# Altium schematic sheet CTRL.SchDoc
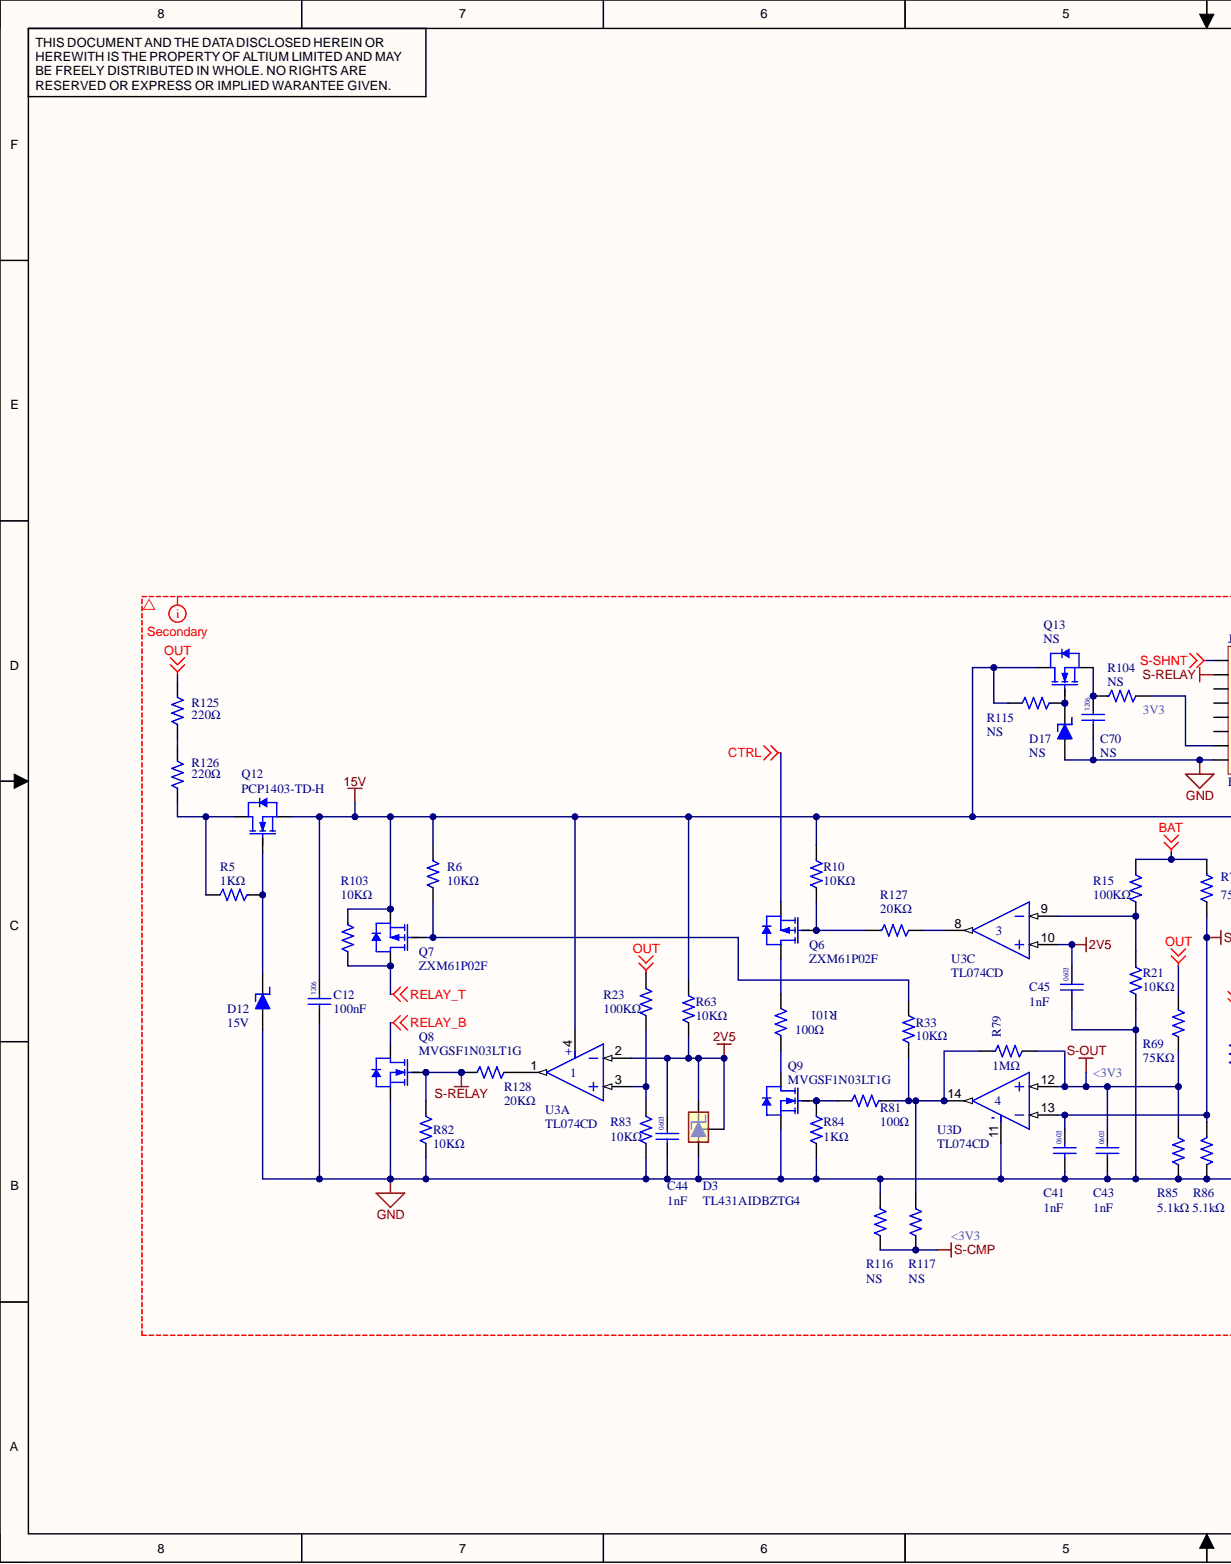
[diagram p0: CTRL.SchDoc, left]
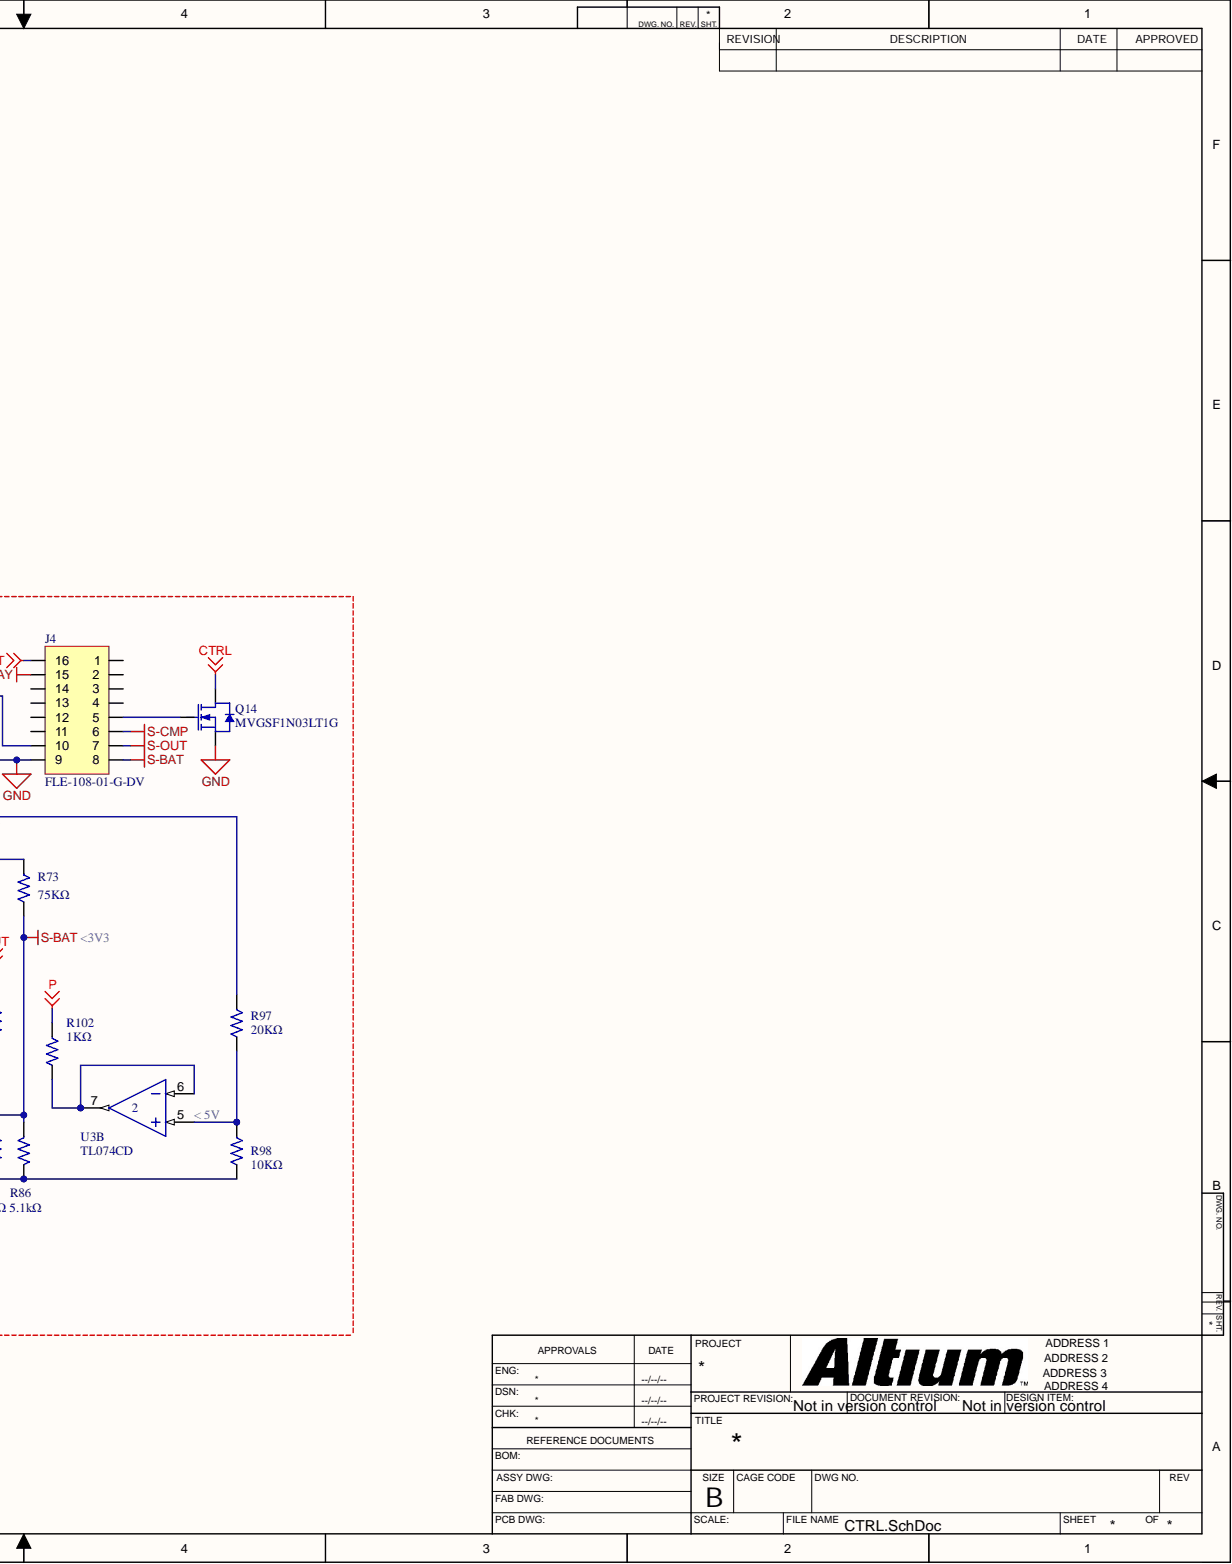
[diagram p1: CTRL.SchDoc, right]

## Parts
C12  value 100nF  Manufacturer Part Number 1: 1206 100nF  Package: 1206  [CC/NM 1206]
C41  value 1nF  Manufacturer Part Number 1: 0603 1nF  Package: 0603  [CC/NM 0603]
C43  value 1nF  Manufacturer Part Number 1: 0603 1nF  Package: 0603  [CC/NM 0603]
C44  value 1nF  Package: 0603  [CC/NM 0603]
C45  value 1nF  Manufacturer Part Number 1: 0603 1nF  Package: 0603  [CC/NM 0603]
C70  value NS  Manufacturer Part Number 1: NS  Package: 1206  [CC/NM 1206]
D3  TL431AIDBZTG4  [SOT95P237X112-3N]
D12  value 15V  [SOT95P237X112-3N]
D17  value NS  Manufacturer Part Number 1: NS  [SOT95P237X112-3N]
J4  FLE-108-01-G-DV  [FLE-108-01-G-DV]
Q6  ZXM61P02F  [SOT95P237X112-3N]
Q7  ZXM61P02F  [SOT95P237X112-3N]
Q8  MVGSF1N03LT1G  [SOT95P237X112-3N]
Q9  MVGSF1N03LT1G  [SOT95P237X112-3N]
Q12  PCP1403-TD-H  [SOT89/4]
Q13  PCP1403-TD-H  value NS  Manufacturer Part Number 1: NS  [SOT89/4]
Q14  MVGSF1N03LT1G  [SOT95P237X112-3N]
R5  value 1KΩ  [CC/NM 0603]
R6  value 10KΩ  [CC/NM 0603]
R10  value 10KΩ  [CC/NM 0603]
R15  value 100KΩ  [CC/NM 0603]  (hidden comment: Resistor 100K)
R21  value 10KΩ  [CC/NM 0603]
R23  value 100KΩ  [CC/NM 0603]  (hidden comment: Resistor 100K)
R33  value 10KΩ  [CC/NM 0603]  (hidden comment: Resistor 100)
R63  value 10KΩ  [CC/NM 0603]
R69  value 75KΩ  [CC/NM 0603]  (hidden comment: Resistor 150K)
R73  value 75KΩ  [CC/NM 0603]  (hidden comment: Resistor 150K)
R79  value 1MΩ  [CC/NM 0603]  (hidden comment: Resistor 150K)
R81  value 100Ω  [CC/NM 0603]  (hidden comment: Resistor 100)
R82  value 10KΩ  [CC/NM 0603]
R83  value 10KΩ  [CC/NM 0603]
R84  value 1KΩ  [CC/NM 0603]
R85  value 5.1kΩ  [CC/NM 0603]
R86  value 5.1kΩ  [CC/NM 0603]
R97  value 20KΩ  [CC/NM 0603]  (hidden comment: Resistor 20K)
R98  value 10KΩ  [CC/NM 0603]  (hidden comment: Resistor 10K)
R101  value 100Ω  [CC/NM 0603]  (hidden comment: Resistor 100)
R102  value 1KΩ  [CC/NM 0603]
R103  value 10KΩ  [CC/NM 0603]
R104  value NS  Manufacturer Part Number 1: NS  [CC/NM 0603]  (hidden comment: Resistor 1)
R115  value NS  Manufacturer Part Number 1: NS  [CC/NM 0603]
R116  value NS  Manufacturer Part Number 1: NS  [CC/NM 0603]  (hidden comment: Resistor 8K2)
R117  value NS  Manufacturer Part Number 1: NS  [CC/NM 0603]  (hidden comment: Resistor 30K)
R125  value 220Ω  [CC/NM 1206]  (hidden comment: Resistor 220)
R126  value 220Ω  [CC/NM 1206]  (hidden comment: Resistor 220)
R127  value 20KΩ  [CC/NM 0603]
R128  value 20KΩ  [CC/NM 0603]
U3  TL074CD  [SOIC14]  (parts A,B,C,D)

## Nets
2V5: C44.1 C45.1 D3.1 D3.2 R63.2 U3.2 U3.10
15V: C12.1 Q7.2 Q12.2 Q13.3 R6.1 R10.1 R63.1 R97.1 R103.1 R115.2 U3.4
BAT: R15.1 R73.1
CTRL: Q6.2 Q14.3
GND: C12.2 C41.2 C43.2 C44.2 C45.2 C70.2 D3.3 D12.1 D17.1 J4.9 Q8.2 Q9.2 Q14.2 R21.2 R82.2 R83.2 R84.2 R85.2 R86.2 R98.2 R116.1 U3.11
OUT: R23.1 R69.1 R125.1
P: R102.1
RELAY_B: Q8.3
RELAY_T: Q7.3 R103.2
S-BAT: C41.1 J4.8 R73.2 R86.1 U3.13
S-CMP: J4.6 R116.2 R117.2
S-OUT: C43.1 J4.7 R69.2 R79.2 R85.1 U3.12
S-RELAY: J4.15 Q8.1 R82.1 R128.1
S-SHNT: J4.16
NetC70_1: C70.1 Q13.2 R104.1
NetD12_3: D12.3 Q12.1 R5.1
NetD17_3: D17.3 Q13.1 R115.1
NetJ4_5: J4.5 Q14.1
NetJ4_10: J4.10 R104.2
NetQ6_1: Q6.1 R10.2 R127.1
NetQ6_3: Q6.3 R101.2
NetQ7_1: Q7.1 R6.2 R33.2
NetQ9_1: Q9.1 R81.2 R84.1
NetQ9_3: Q9.3 R101.1
NetQ12_3: Q12.3 R5.2 R126.2
NetR15_2: R15.2 R21.1 U3.9
NetR23_2: R23.2 R83.1 U3.3
NetR33_1: R33.1 R79.1 R81.1 R117.1 U3.14
NetR97_2: R97.2 R98.1 U3.5
NetR102_2: R102.2 U3.6 U3.7
NetR125_2: R125.2 R126.1
NetR127_2: R127.2 U3.8
NetR128_2: R128.2 U3.1

Not connected: J4 1 2 3 4 11 12 13 14

## Library data of the parts
C12 C70 = Capacitor 100nF: 100nF; Component Kind: Standard; Library Name: Capacitors_1206.SchLib; Price 1k Ud.: 0.01
  C12: Manufacturer 1: -; Supplier 1: -; Supplier Part Number 1: -
C41 C43 C45 = Capacitor 1nF: 1nF; Component Kind: Standard; Library Name: Capacitors_0603.SchLib; Manufacturer 1: -; Price 1k Ud.: 0.01; Supplier 1: -; Supplier Part Number 1: -
C44 = Capacitor 1nF: 1nF; Manufacturer Part Number 1: 0603 1nF; Component Kind: Standard; Library Name: Capacitors_0603.SchLib; Manufacturer 1: -; Price 1k Ud.: 0.01; Supplier 1: -; Supplier Part Number 1: -
D3 = TL431AIDBZTG4: TL43xx Precision Programmable Reference; Manufacturer 1: TEXAS INSTRUMENTS; Manufacturer Part Number 1: ATL431AIDBZR; Value: 2.495V 1% 36V; Component Kind: Standard; Datasheet: http://www.ti.com/lit/ds/symlink/tl431a.pdf; Library Name: Charger.SchLib; Package: SOT-23-3; Supplier 1: Farnell; Supplier Part Number 1: 3124355
D12 = Zener_18V: Manufacturer 1: ON SEMICONDUCTOR; Manufacturer Part Number 1: BZX84C18LT1G; Component Kind: Standard; Datasheet: http://www.farnell.com/datasheets/2236792.pdf?_ga=2.213210964.1976199833.1527489872-1776635558.1522923271&_gac=1.262728446.1526985275.CjwKCAjw_47YBRBxEiwAYuKdww9b6sMrV3bL46mkZpXa3C9ombUFUaiop7L4r4spXLG7BMEaHASa6xoCLfwQAvD_BwE; Library Name: Charger.SchLib; Package: SOT-23-3; Price 1k Ud.: 0.01; Supplier 1: Farnell; Supplier Part Number 1: 1651579
D17 = Zener_18V: Manufacturer 1: ON SEMICONDUCTOR; Component Kind: Standard; Datasheet: http://www.farnell.com/datasheets/2239339.pdf?_ga=2.139736627.1877481321.1537857536-1776635558.1522923271; Library Name: Charger.SchLib; Package: SOT-23-3; Price 1k Ud.: 0.01; Supplier 1: Farnell; Supplier Part Number 1: 2690054
J4 = FLE-108-01-G-DV: Manufacturer 1: Samtec; Value: NS; Component Kind: Standard; Datasheet: https://www.mouser.es/datasheet/2/527/fle_sm-1369928.pdf; Description: FLE-108-01-G-DV; Library Name: Charger.SchLib; Manufacturer Part Number 1: FLE-108-01-G-DV; Package: SMD; Supplier 1: Mouser; Supplier Part Number 1: 200-FLE10801GDV
Q6 Q7 = ZXM61P02F: PMOS  -20V 0.9A 600 mohm; Manufacturer 1: DIODES INC.; Component Kind: Standard; Datasheet: http://www.farnell.com/datasheets/1899048.pdf?_ga=2.230156447.2046274455.1537515722-1776635558.1522923271; Library Name: Charger.SchLib; Manufacturer Part Number 1: ZXM61P02F; Package: SOT-23-3; Supplier 1: Farnell; Supplier Part Number 1: 9525297; Value: 20V 0.9A
Q8 Q9 = MVGSF1N03LT1G: NMOS 30V 2.1A  80mR; Manufacturer 1: ON SEMICONDUCTOR; Component Kind: Standard; Datasheet: http://www.farnell.com/datasheets/1749672.pdf?_ga=2.191310249.2046274455.1537515722-1776635558.1522923271; Library Name: Charger.SchLib; Manufacturer Part Number 1: MVGSF1N03LT1G; Package: SOT-23-3; Supplier 1: Farnell; Supplier Part Number 1: 2630354; Value: 30V 2.1A
Q12 Q13 = PCP1403-TD-H: NMOS 60V 4.5A 117mR; Manufacturer 1: ON SEMICONDUCTOR; Component Kind: Standard; Datasheet: https://www.mouser.es/datasheet/2/308/ENA2294-D-336362.pdf; Library Name: Charger.SchLib; Package: SOT-89-3; Supplier 1: Mouser; Supplier Part Number 1: 863-PCP1403-TD-H
  Q12: Manufacturer Part Number 1: PCP1403-TD-H; Value: 60V 4.5A 117mR
Q14 = MVGSF1N03LT1G: NMOS 30V 2.1A  80mR; Manufacturer 1: ON SEMICONDUCTOR; Manufacturer Part Number 1: NS; Value: NS; Component Kind: Standard; Datasheet: http://www.farnell.com/datasheets/1749672.pdf?_ga=2.191310249.2046274455.1537515722-1776635558.1522923271; Library Name: Charger.SchLib; Package: SOT-23-3; Supplier 1: Farnell; Supplier Part Number 1: 2630354
R5 = Resistor 1K: Resistor 0603; Manufacturer Part Number 1: 0603 1K; Component Kind: Standard; Library Name: Resistors_0603.SchLib; Package: 0603; Price 1k Ud.: 0.01
R6 R10 R21 R63 R82 R83 R98 R103 = Resistor 10K: Resistor 0603; Manufacturer Part Number 1: 0603 10K; Component Kind: Standard; Library Name: Resistors_0603.SchLib; Package: 0603
  R6 R10 R21 R63 R82 R83 R103: Price 1k Ud.: 0.01
R15 R23 = Resistor 100K: Resistor 0603; Manufacturer Part Number 1: 0603 100K; Component Kind: Standard; Library Name: Resistors_0603.SchLib; Package: 0603
R33 = Resistor 100: Resistor 0603; Manufacturer Part Number 1: 0603 10K; Component Kind: Standard; Library Name: Resistors_0603.SchLib; Package: 0603; Price 1k Ud.: 0.01
R69 R73 = Resistor 150K: Resistor 0603; Manufacturer Part Number 1: 0603 75K; Component Kind: Standard; Library Name: Resistors_0603.SchLib; Package: 0603
R79 = Resistor 150K: Resistor 0603; Manufacturer Part Number 1: 0603 1M; Value: 1MΩ; Component Kind: Standard; Library Name: Resistors_0603.SchLib; Package: 0603
R81 R101 = Resistor 100: Resistor 0603; Manufacturer Part Number 1: 0603 100R; Component Kind: Standard; Library Name: Resistors_0603.SchLib; Package: 0603; Price 1k Ud.: 0.01
R84 R102 = Resistor 10K: Resistor 0603; Manufacturer Part Number 1: 0603 1K; Component Kind: Standard; Library Name: Resistors_0603.SchLib; Package: 0603; Price 1k Ud.: 0.01
R85 R86 = Resistor 10K: Resistor 0603; Manufacturer Part Number 1: 0603 5K1; Component Kind: Standard; Library Name: Resistors_0603.SchLib; Package: 0603; Price 1k Ud.: 0.01
R97 = Resistor 20K: Resistor 0603; Manufacturer Part Number 1: 0603 20K; Component Kind: Standard; Library Name: Resistors_0603.SchLib; Package: 0603
R104 = Resistor 1: Resistor 0603; Component Kind: Standard; Library Name: Resistors_0603.SchLib; Package: 0603
R115 = Resistor 1K: Resistor 0603; Component Kind: Standard; Library Name: Resistors_0603.SchLib; Package: 0603; Price 1k Ud.: 0.01
R116 = Resistor 8K2: Resistor 0603; Component Kind: Standard; Library Name: Resistors_0603.SchLib; Package: 0603
R117 = Resistor 30K: Resistor 0603; Component Kind: Standard; Library Name: Resistors_0603.SchLib; Package: 0603
R125 R126 = Resistor 220: Resistor 1206; Manufacturer Part Number 1: 1206 220R; Component Kind: Standard; Library Name: Resistors_1206.SchLib; Package: 1206
R127 = Resistor 10K: Resistor 0603; Manufacturer Part Number 1: 0603 20K; Component Kind: Standard; Library Name: Resistors_0603.SchLib; Package: 0603; Price 1k Ud.: 0.01
R128 = Resistor 1K: Resistor 0603; Manufacturer Part Number 1: 0603 20K; Value: 20KΩ; Component Kind: Standard; Library Name: Resistors_0603.SchLib; Package: 0603; Price 1k Ud.: 0.01
U3 = TL074CD: 3 MHz, 13 V/µs, 7V a 36V; Manufacturer 1: TEXAS INSTRUMENTS; Value: 36V 3MHz; Component Kind: Standard; Datasheet: http://www.ti.com/lit/ds/symlink/tl074.pdf; Library Name: Charger.SchLib; Manufacturer Part Number 1: TL074CD; Package: SOIC-14; Supplier 1: Farnell; Supplier Part Number 1: 1103010
